# Altium schematic sheet Power.SchDoc(U_Power)
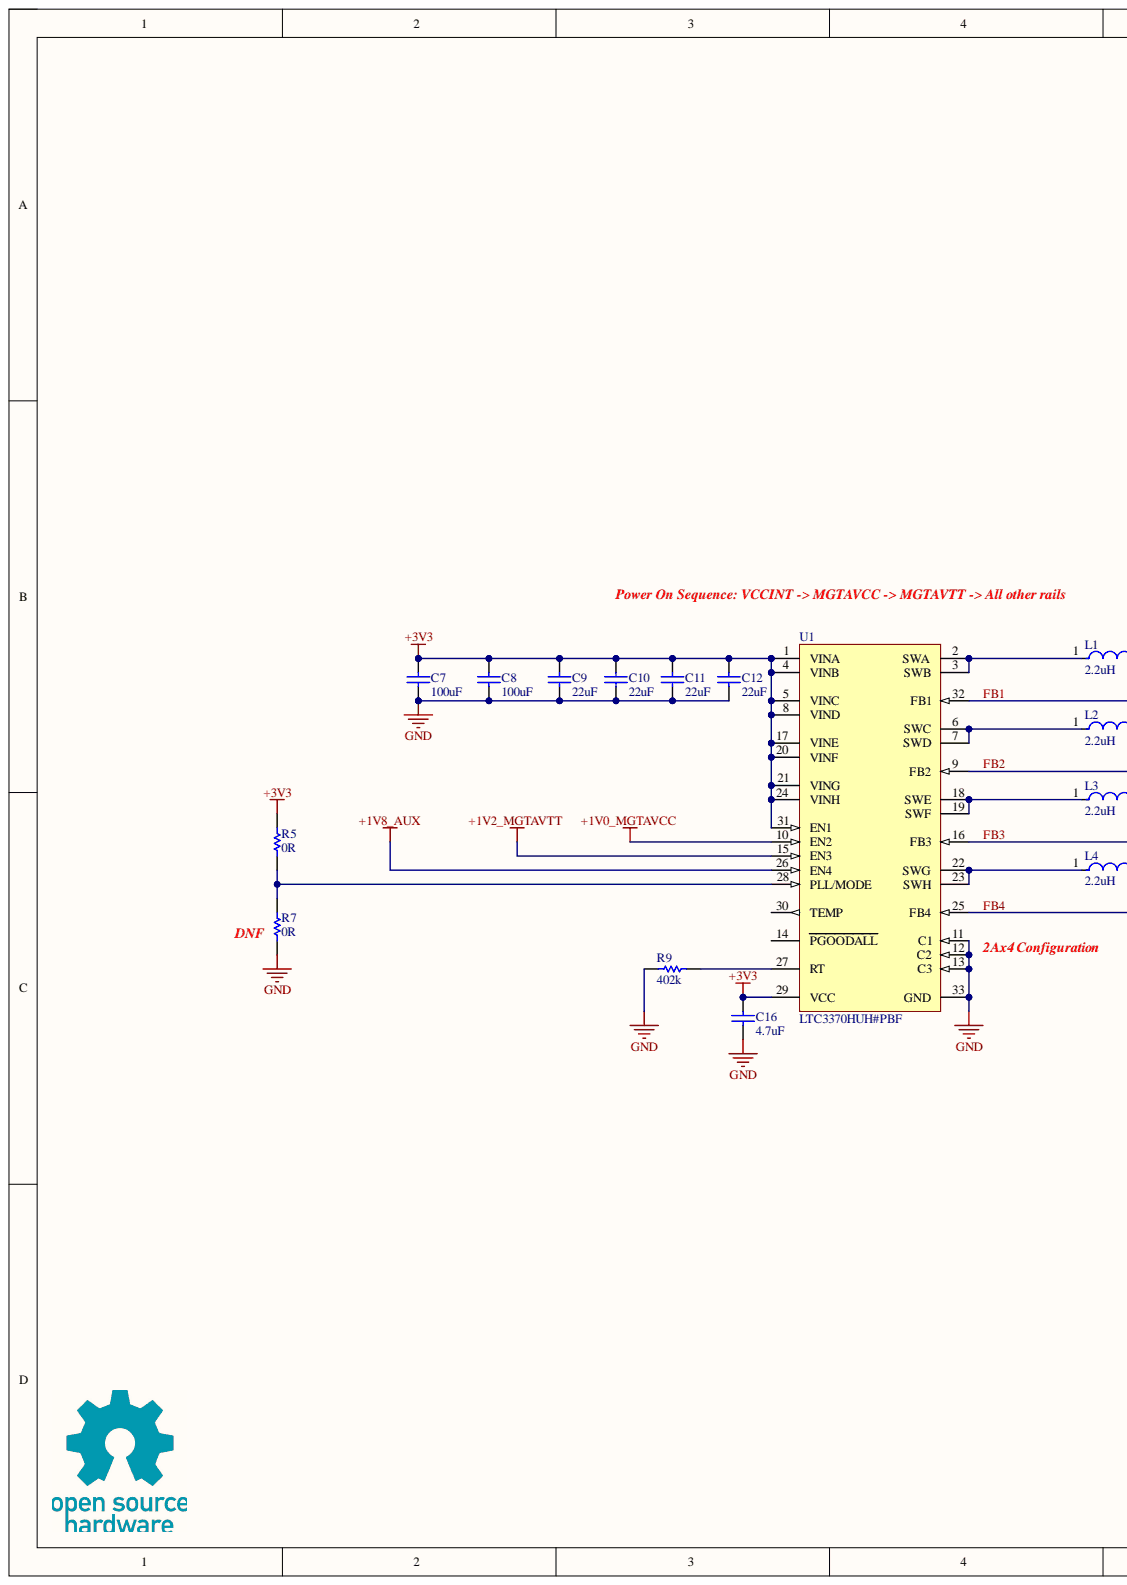
[diagram p0: Power.SchDoc, left]
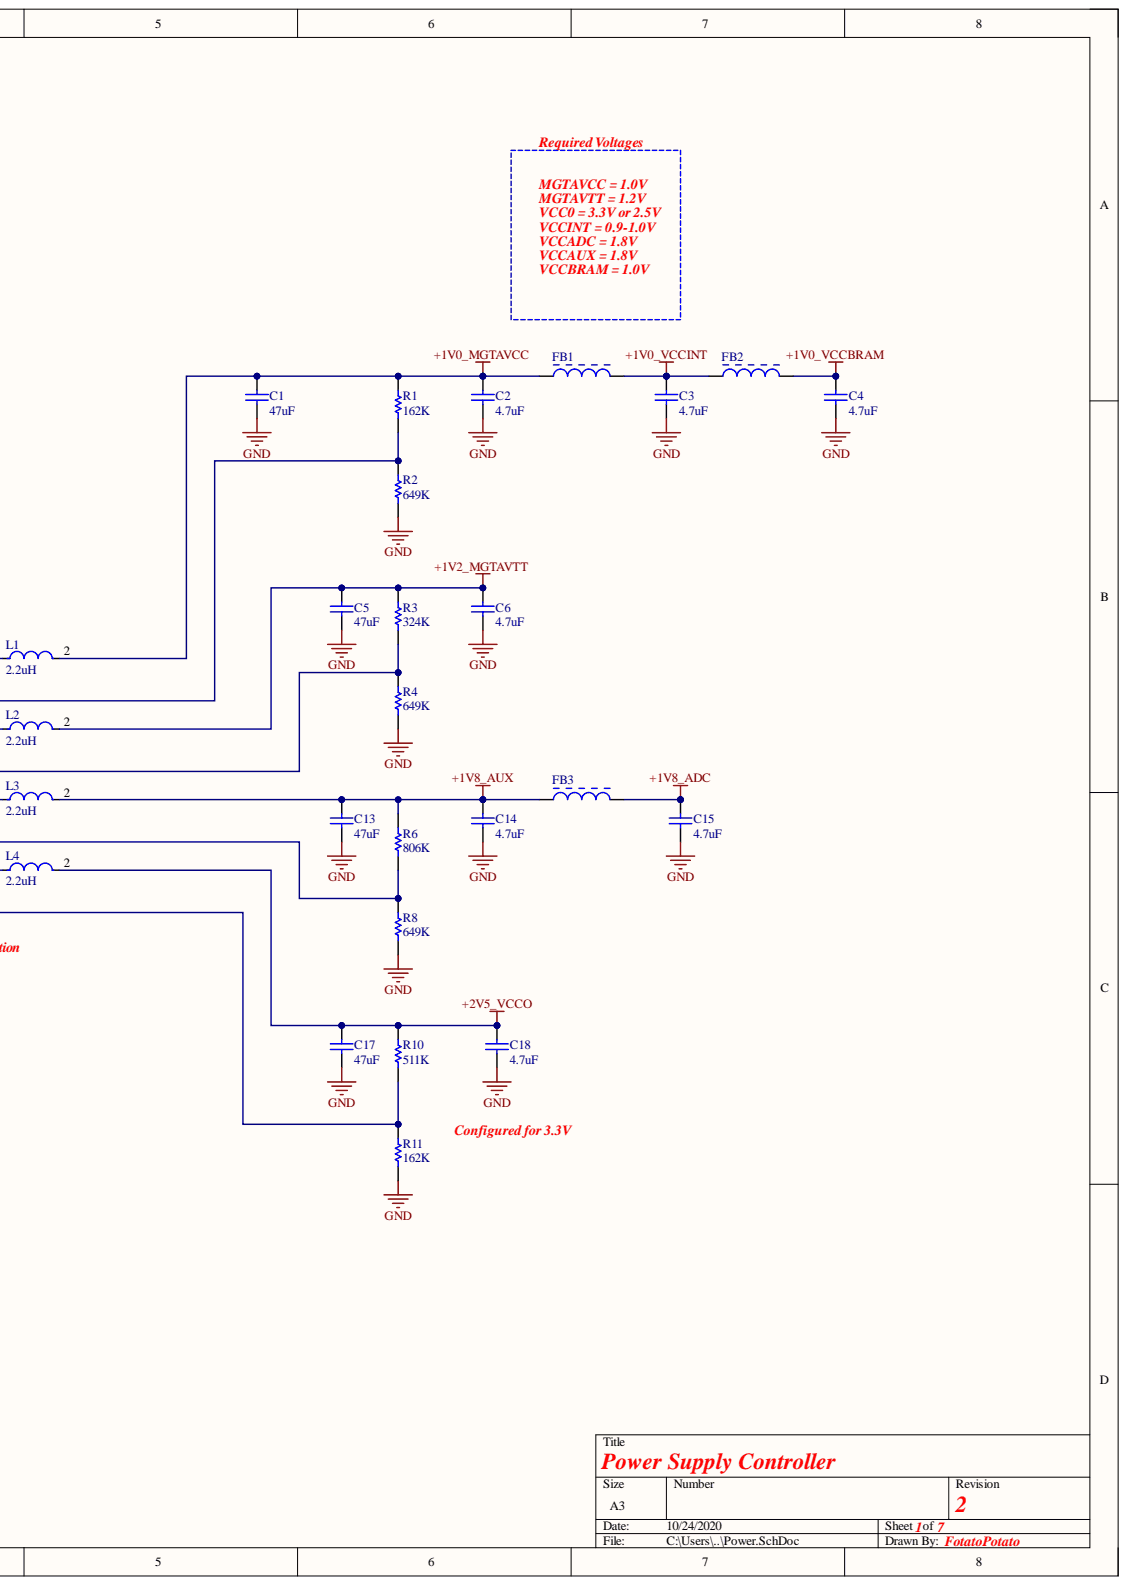
[diagram p1: Power.SchDoc, right]

## Parts
C1  47uF  [CAPC2013X145X45NL20T25]
C2  4.7uF  [CAPC1608X90X35ML10T15]
C3  4.7uF  [CAPC1608X90X35ML10T15]
C4  4.7uF  [CAPC1608X90X35ML10T15]
C5  47uF  [CAPC2013X145X45NL20T25]
C6  4.7uF  [CAPC1608X90X35ML10T15]
C7  100uF  [CAPC3216X190X55ML30T25]
C8  100uF  [CAPC3216X190X55ML30T25]
C9  22uF  [CAPC2013X140X45NL15T25]
C10  22uF  [CAPC2013X140X45NL15T25]
C11  22uF  [CAPC2013X140X45NL15T25]
C12  22uF  [CAPC2013X140X45NL15T25]
C13  47uF  [CAPC2013X145X45NL20T25]
C14  4.7uF  [CAPC1608X90X35ML10T15]
C15  4.7uF  [CAPC1608X90X35ML10T15]
C16  4.7uF  [CAPC1608X90X35ML10T15]
C17  47uF  [CAPC2013X145X45NL20T25]
C18  4.7uF  [CAPC1608X90X35ML10T15]
FB1  BLM18PG121SN1D  [MURA-BLM18PG_KG-B25-CHIP-2_V1]  (value not drawn)
FB2  BLM18PG121SN1D  [MURA-BLM18PG_KG-B25-CHIP-2_V1]  (value not drawn)
FB3  BLM18PG121SN1D  [MURA-BLM18PG_KG-B25-CHIP-2_V1]  (value not drawn)
L1  2.2uH  [FP-IHLP-2020BZ-FP_1_905x2-MFG]
L2  2.2uH  [FP-IHLP-2020BZ-FP_1_905x2-MFG]
L3  2.2uH  [FP-IHLP-2020BZ-FP_1_905x2-MFG]
L4  2.2uH  [FP-IHLP-2020BZ-FP_1_905x2-MFG]
R1  162K  [RESC1005X40X25LL05T05]
R2  649K  [RESC0402(1005)_N]
R3  324K  [RESC0402(1005)_M]
R4  649K  [RESC0402(1005)_N]
R5  0R  [RESC1005X40X25ML05T10]
R6  806K  [RESC1005X40X25ML05T05]
R7  0R  [RESC1005X40X25ML05T10]
R8  649K  [RESC0402(1005)_N]
R9  402k  [RESC1005X40X25LL05T05]
R10  511K  [RESC1005X40X25NL05T05]
R11  162K  [RESC1005X40X25LL05T05]
U1  LTC3370HUH#PBF  [LT-UH-32_L]

## Nets
+1V0_MGTAVCC: C1.2 C2.2 FB1.1 L1.2 R1.2 U1.10(EN2)
+1V0_VCCBRAM: C4.2 FB2.2
+1V0_VCCINT: C3.2 FB1.2 FB2.1
+1V2_MGTAVTT: C5.2 C6.2 L2.2 R3.2 U1.15(EN3)
+1V8_ADC: C15.2 FB3.2
+1V8_AUX: C13.2 C14.2 FB3.1 L3.2 R6.2 U1.26(EN4)
+2V5_VCCO: C17.2 C18.2 L4.2 R10.2
+3V3: C7.2 C8.2 C9.2 C10.2 C11.2 C12.2 C16.2 R5.2 U1.1(VINA) U1.4(VINB) U1.5(VINC) U1.8(VIND) U1.17(VINE) U1.20(VINF) U1.21(VING) U1.24(VINH) U1.29(VCC) U1.31(EN1)
GND: C1.1 C2.1 C3.1 C4.1 C5.1 C6.1 C7.1 C8.1 C9.1 C10.1 C11.1 C12.1 C13.1 C14.1 C15.1 C16.1 C17.1 C18.1 R2.1 R4.1 R7.1 R8.1 R9.1 R11.1 U1.11(C1) U1.12(C2) U1.13(C3) U1.33(GND)
FB1: R1.1 R2.2 U1.32(FB1)
FB2: R3.1 R4.2 U1.9(FB2)
FB3: R6.1 R8.2 U1.16(FB3)
FB4: R10.1 R11.2 U1.25(FB4)
NetL1_1: L1.1 U1.2(SWA) U1.3(SWB)
NetL2_1: L2.1 U1.6(SWC) U1.7(SWD)
NetL3_1: L3.1 U1.18(SWE) U1.19(SWF)
NetL4_1: L4.1 U1.22(SWG) U1.23(SWH)
NetR5_1: R5.1 R7.2 U1.28(PLL/MODE)
NetR9_2: R9.2 U1.27(RT)

Not connected: U1 14(PGOODALL) 30(TEMP)

## Library data of the parts
C1 C5 C13 C17 = CMP-2007-03221-1: Manufacturer: Murata; Part Number: GRM21BR60J476ME15L; Component Kind: Standard; Library Name: Altium Content Vault
C2-C4 C6 C14-C16 C18 = CMP-2006-02799-2: Chip Capacitor, 4.7 uF, +/- 10%, 6.3 V, -55 to 85 degC, 0603 (1608 Metric), RoHS, Tape and Reel; PartNumber: GRM188R60J475KE19D; Type (Capacitors): X5R; Voltage Rating: 6.3 V; Voltage Rating (DC): 3 V; Capacitance: 4.7 uF; Case Code (Imperial): 0603; Case Code (Metric): 1608; Case/Package: 0603; Component Kind: Standard; ComponentLink1Description: Manufacturer URL; ComponentLink1URL: http://www.murata.com/; ComponentLink2Description: Datasheet; ComponentLink2URL: http://psearch.en.murata.com/capacitor/product/GRM188R60J475KE19%23.pdf; DatasheetVersion: 08/2016; Height: 0.8 mm; Length: 1.6 mm; Library Name: Altium Content Vault; Max Operating Temperature: 85 degC; Min Operating Temperature: -55 degC; Mount: Surface Mount; Mounting Technology: Surface Mount; Package Quantity: 4000; PackageDescription: 2-Pin Surface Mount Device, Body 1.6 x 0.8 mm; Packaging: Tape and Reel; Radiation Hardening: No; REACH SVHC: No SVHC; RoHSCompliant: true; Tolerance: 10%; Width: 0.8 mm
C7 C8 = CMP-1662-00011-2: Chip Capacitor, 100 uF, +/- 20%, 6.3 V, -55 to 85 degC, 1206 (3216 Metric), RoHS, Tape and Reel; PartNumber: GRM31CR60J107ME39L; Case\/Package: 1206; Type (Capacitors): X5R; Voltage Rating: 6.3 V; Capacitance: 100 uF; Case Code (Imperial): 1206; Case Code (Metric): 3216; Component Kind: Standard; ComponentLink1Description: Manufacturer URL; ComponentLink1URL: http://www.murata.com/; ComponentLink2Description: Datasheet; ComponentLink2URL: http://psearch.en.murata.com/capacitor/product/GRM31CR60J107ME39%23.pdf; DatasheetVersion: 09/2016; Height: 1.6 mm; Length: 3.2 mm; Library Name: Altium Content Vault; Max Operating Temperature: 85 degC; Min Operating Temperature: -55 degC; Mount: Surface Mount; Mounting Technology: Surface Mount; Package Quantity: 2000; PackageDescription: 2-Pin Surface Mount Device, Body 3.2 x 1.6 mm; PackageReference: 1206; Packaging: Tape and Reel; Pins: 2; Radiation Hardening: No; REACH SVHC: No SVHC; RoHSCompliant: true; Tolerance: 20%; Voltage Rating (DC): 6.3 V; Width: 1.6 mm
C9-C12 = CMP-2007-03385-1: Manufacturer: Murata; Part Number: GRM21BR60J226ME39L; Component Kind: Standard; Library Name: Altium Content Vault
FB1-FB3 = CMP-0686-00557-6: Chip Ferrite Bead for Power Lines, 120 Ohm, 2000 mA, -55 to 125 degC, 1.6 x 0.8 x 0.95 mm SMD, Tape and Reel; Manufacturer: Murata; DC Resistance: 0.05 Ohm Max.; Impedance (at 100 MHz): 120 Ohm +/- 25%; Rated Current: 2000 mA; Component Kind: Standard; ComponentLink1Description: Manufacturer URL; ComponentLink1URL: http://www.murata.com/; ComponentLink2Description: Datasheet; ComponentLink2URL: http://www.murata.com/products/catalog/pdf/c31e.pdf; ComponentLink3Description: Package Specification; ComponentLink3URL: http://www.murata.com/products/catalog/pdf/c31e.pdf; DatasheetVersion: 08/2013; Library Name: Altium Content Vault; Mounting Technology: Surface Mount; Operating Temperature Range: -55 to 125 degC; PackageDescription: 2-Pin Chip, Body 1.6 x 0.8 mm; PackageReference: BLM18_H950-2; Packing: Tape and Reel; PartNumber: BLM18PG121SN1D
L1-L4 = CMP-02424-000260-1: Fixed Inductors Commercial Low DCR Series 2.2uH 5A 37.7mΩ 20%; Core Material: Metal; DC Resistance (DCR): 37.7mR; Max DC Current: 5.5A; Self Resonant Frequency: 41MHz; Shielding: Shielded; Test Frequency: 100kHz; Case/Package: 2020; Component Kind: Standard; Contact Plating: Tin; Current Rating: 5A; Depth: 5.43mm; Height: 2mm; Height - Seated (Max): 0.079inch; Inductance: 2.2uH; Inductance Tolerance: 20%; Lead Free: Lead Free; Length: 5.74mm; Library Name: Altium Content Vault; Max Operating Temperature: 125°C; Military Standard: Not; Min Operating Temperature: -55°C; Mount: Surface Mount; Number of Pins: 2; Package Quantity: 2000; Packaging: Tape and Reel; Radiation Hardening: No; RMS Current (Irms): 5A; Saturation Current: 5.5A; Termination: SMD/SMT; Tolerance: 20%; Width: 0.204inch
R1 R11 = CMP-2002-08230-1: Manufacturer: Vishay Dale; Part Number: CRCW0402162KFKED; Component Kind: Standard; Library Name: Altium Content Vault
R2 R4 R8 = CMP-1011-00828-1: 649K 0.063W 1% 0402 (1005 Metric)  SMD; Case-EIA: 0402; Case-Metric: 1005; Value: 649000.00 Ohm; Component Kind: Standard; Library Name: Altium Content Vault; Power: 0.063 W; Technology: SMT; Tolerance: 1 %
R3 = CMP-1011-00792-1: 324K 0.063W 1% 0402 (1005 Metric)  SMD; Case-EIA: 0402; Case-Metric: 1005; Value: 324000.00 Ohm; Component Kind: Standard; Library Name: Altium Content Vault; Power: 0.063 W; Technology: SMT; Tolerance: 1 %
R5 R7 = CMP-2002-03041-1: Manufacturer: Vishay Dale; Part Number: CRCW04020000Z0EDHP; Component Kind: Standard; Library Name: Altium Content Vault
R6 = CMP-2002-00226-1: Manufacturer: Vishay Dale; Part Number: CRCW0402806KFKED; Component Kind: Standard; Library Name: Altium Content Vault
R9 = CMP-2002-08615-1: Manufacturer: Vishay Dale; Part Number: CRCW0402402KFKED; Component Kind: Standard; Library Name: Altium Content Vault
R10 = CMP-2002-00076-1: Manufacturer: Vishay Dale; Part Number: CRCW0402511KFKED; Component Kind: Standard; Library Name: Altium Content Vault
U1 = CMP-0492-02692-1: 4-Channel 8A Configurable Buck DC/DC, 2.25 to 5.5 V VIN, -40 to 150 degC, 32-Pin QFN (UH), RoHS, Tube; VCC Max: 5.5 V; VCC Min: 2.7 V; VIN Min: 2.25 V; Code_JEDEC: MO-220; Component Kind: Standard; ComponentLink1Description: Manufacturer URL; ComponentLink1URL: http://www.linear.com/; ComponentLink2Description: Datasheet; ComponentLink2URL: http://cds.linear.com/docs/en/datasheet/3370f.pdf; ComponentLink3Description: Package Specification; ComponentLink3URL: http://cds.linear.com/docs/en/packaging/QFN_32_05-08-1693.pdf; Library Name: Altium Content Vault; Mounting Technology: Surface Mount; PackageDescription: 32-Pin Plastic Quad Flat No-lead Package, Body 5 x 5 mm, Pitch 0.5 mm; PackageVersion: Rev. D, 06/2010; Packing: Tube; PartNumber: LTC3370HUH#PBF; RoHS: TRUE; Temperature Max: 150 degC; Temperature Min: -40 degC; VIN Max: 5.5 V
